# Altium schematic sheet Main.SchDoc
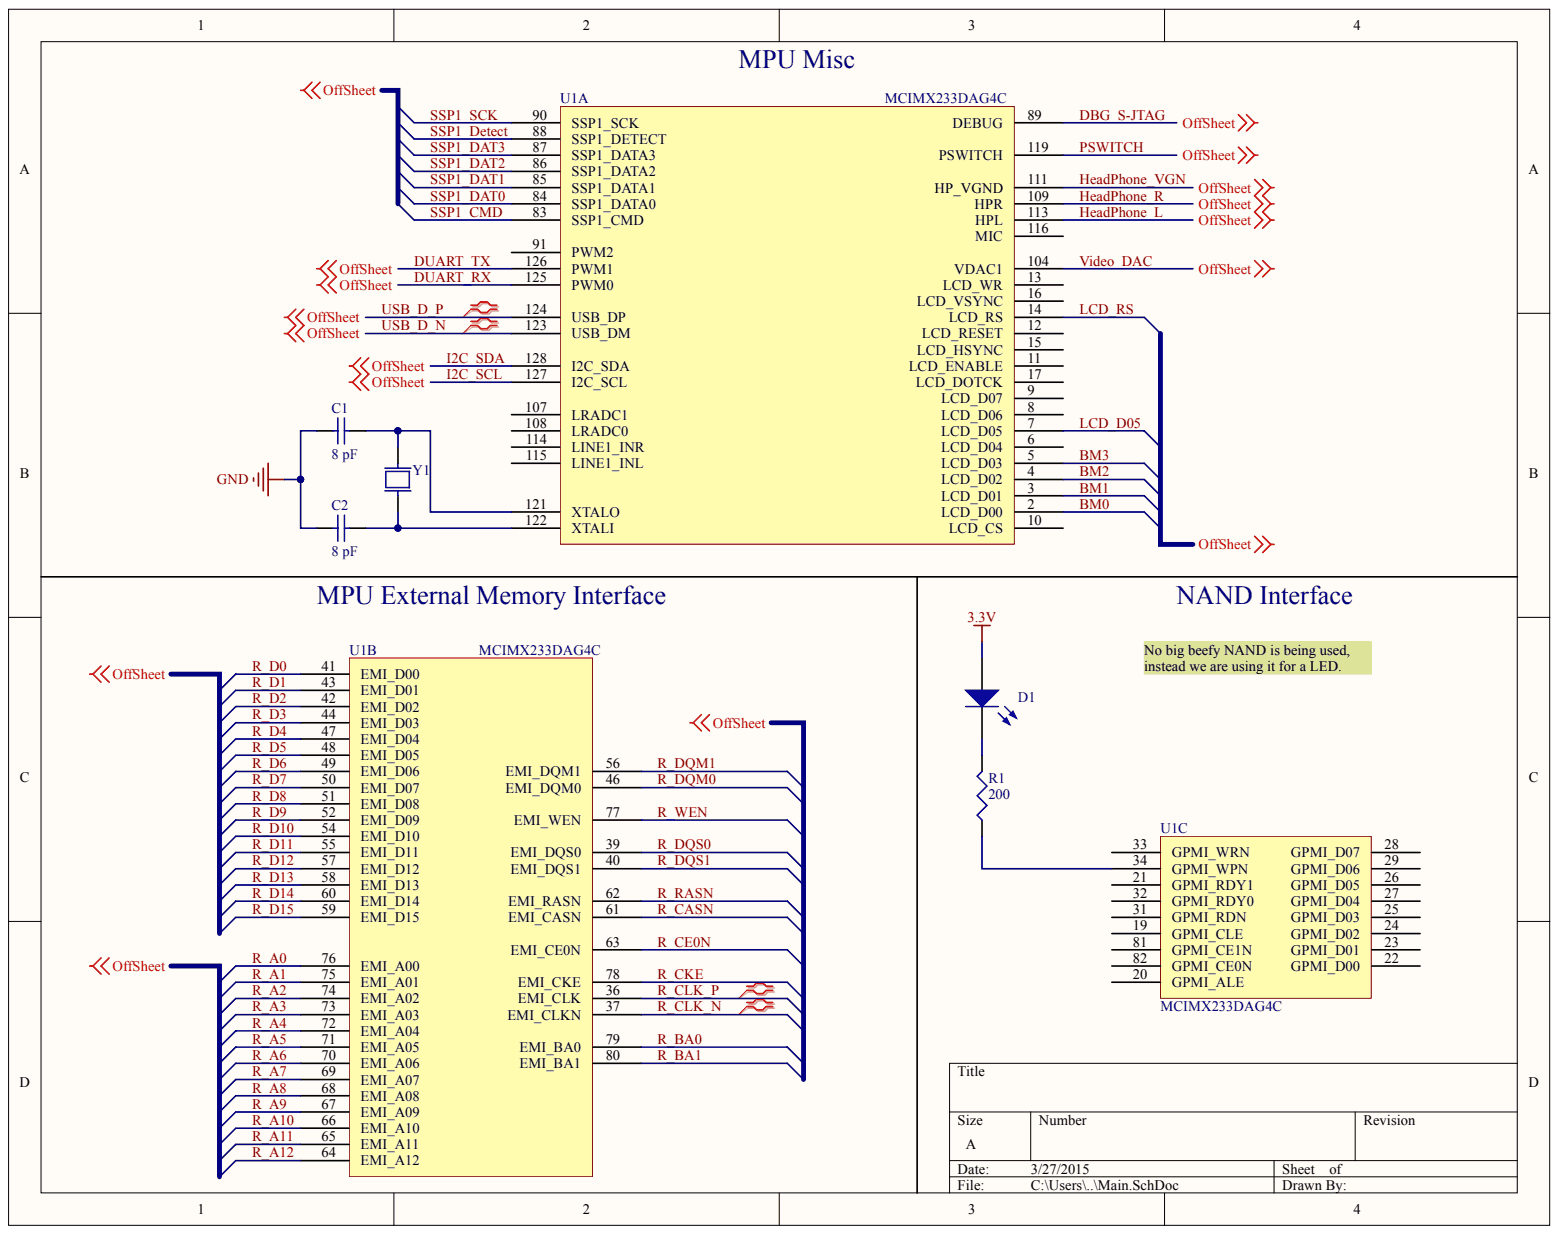
[diagram p0: Main.SchDoc]

## Parts
C1  value 8 pF  [0402]  (hidden comment: GRM1555C1H8R0DA01D)
C2  value 8 pF  [0402]  (hidden comment: GRM1555C1H8R0DA01D)
D1  LSQ976-Z  [LSQ976-Z]  (value not drawn)
R1  value 200  [J1-0603]  (hidden comment: MCR03ERTJ201)
U1  MCIMX233DAG4C  [TSQFP40P1600X1600X160-128N]  (parts A,B,C)
Y1  XRCGB24M000F3M00R0  [XRCGB24M000F3M00R0]  (value not drawn)

## Nets
3.3V: D1.A
GND: C1.2 C2.2
BM0: U1.2(LCD_D00)
BM1: U1.3(LCD_D01)
BM2: U1.4(LCD_D02)
BM3: U1.5(LCD_D03)
DBG_S-JTAG: U1.89(DEBUG)
DUART_RX: U1.125(PWM0)
DUART_TX: U1.126(PWM1)
HEADPHONE_L: U1.113(HPL)
HEADPHONE_R: U1.109(HPR)
HEADPHONE_VGN: U1.111(HP_VGND)
I2C_SCL: U1.127(I2C_SCL)
I2C_SDA: U1.128(I2C_SDA)
LCD_D05: U1.7(LCD_D05)
LCD_RS: U1.14(LCD_RS)
PSWITCH: U1.119(PSWITCH)
R_A0: U1.76(EMI_A00)
R_A1: U1.75(EMI_A01)
R_A2: U1.74(EMI_A02)
R_A3: U1.73(EMI_A03)
R_A4: U1.72(EMI_A04)
R_A5: U1.71(EMI_A05)
R_A6: U1.70(EMI_A06)
R_A7: U1.69(EMI_A07)
R_A8: U1.68(EMI_A08)
R_A9: U1.67(EMI_A09)
R_A10: U1.66(EMI_A10)
R_A11: U1.65(EMI_A11)
R_A12: U1.64(EMI_A12)
R_BA0: U1.79(EMI_BA0)
R_BA1: U1.80(EMI_BA1)
R_CASN: U1.61(EMI_CASN)
R_CE0N: U1.63(EMI_CE0N)
R_CKE: U1.78(EMI_CKE)
R_CLK_N: U1.37(EMI_CLKN)
R_CLK_P: U1.36(EMI_CLK)
R_D0: U1.41(EMI_D00)
R_D1: U1.43(EMI_D01)
R_D2: U1.42(EMI_D02)
R_D3: U1.44(EMI_D03)
R_D4: U1.47(EMI_D04)
R_D5: U1.48(EMI_D05)
R_D6: U1.49(EMI_D06)
R_D7: U1.50(EMI_D07)
R_D8: U1.51(EMI_D08)
R_D9: U1.52(EMI_D09)
R_D10: U1.54(EMI_D10)
R_D11: U1.55(EMI_D11)
R_D12: U1.57(EMI_D12)
R_D13: U1.58(EMI_D13)
R_D14: U1.60(EMI_D14)
R_D15: U1.59(EMI_D15)
R_DQM0: U1.46(EMI_DQM0)
R_DQM1: U1.56(EMI_DQM1)
R_DQS0: U1.39(EMI_DQS0)
R_DQS1: U1.40(EMI_DQS1)
R_RASN: U1.62(EMI_RASN)
R_WEN: U1.77(EMI_WEN)
SSP1_CMD: U1.83(SSP1_CMD)
SSP1_DAT0: U1.84(SSP1_DATA0)
SSP1_DAT1: U1.85(SSP1_DATA1)
SSP1_DAT2: U1.86(SSP1_DATA2)
SSP1_DAT3: U1.87(SSP1_DATA3)
SSP1_DETECT: U1.88(SSP1_DETECT)
SSP1_SCK: U1.90(SSP1_SCK)
USB_D_N: U1.123(USB_DM)
USB_D_P: U1.124(USB_DP)
VIDEO_DAC: U1.104(VDAC1)
NetC1_1: C1.1 U1.121(XTALO) Y1.3
NetC2_1: C2.1 U1.122(XTALI) Y1.1
NetD1_C: D1.C R1.1
NetR1_2: R1.2 U1.34(GPMI_WPN)
N00017:
N00018:
N00019:
N00020:
N00021:
OFFSHEET:

Not connected: U1 6(LCD_D04) 8(LCD_D06) 9(LCD_D07) 10(LCD_CS) 11(LCD_ENABLE) 12(LCD_RESET) 13(LCD_WR) 15(LCD_HSYNC) 16(LCD_VSYNC) 17(LCD_DOTCK) 19(GPMI_CLE) 20(GPMI_ALE) 21(GPMI_RDY1) 22(GPMI_D00) 23(GPMI_D01) 24(GPMI_D02) 25(GPMI_D03) 26(GPMI_D05) 27(GPMI_D04) 28(GPMI_D07) 29(GPMI_D06) 31(GPMI_RDN) 32(GPMI_RDY0) 33(GPMI_WRN) 81(GPMI_CE1N) 82(GPMI_CE0N) 91(PWM2) 107(LRADC1) 108(LRADC0) 114(LINE1_INR) 115(LINE1_INL) 116(MIC)

## Library data of the parts
C1 C2 = GRM1555C1H8R0DA01D: CAP CER 8PF 50V NP0 0402; Component Kind: Standard; LatestRevisionDate: 29-May-2009; LatestRevisionNote: IPC-7351 Footprint Added.; PackageDescription: Chip Capacitor; PackageReference: C1206; Published: 8-Jun-2000; Signal Integrity: Cap Semi; Simulation: CAP; Supplier 1: Digi-Key; Supplier Part Number 1: 490-5867-1-ND; Library Name: Linux_Board_SCHEM_LIB.SchLib; Publisher: Altium Limited
D1 = LSQ976-Z: OSRAM - LED, SMD, RED, 180MCD, 633NM; Component Kind: Standard; Signal Integrity: Guessed Model; Supplier 1: Mouser; Supplier 2: Newark; Supplier Part Number 1: N/A; Supplier Part Number 2: 05M0541; Library Name: Linux_Board_SCHEM_LIB.SchLib
R1 = MCR03ERTJ201: RES 200 OHM 1/10W 5% 0603 SMD; Component Kind: Standard; LatestRevisionDate: 29-May-2009; LatestRevisionNote: IPC-7351 Footprint Added.; PackageDescription: Chip Resistor; PackageReference: J1-0603; Published: 8-Jun-2000; Signal Integrity: Res; Simulation: RESISTOR; Supplier 1: Digi-Key; Supplier Part Number 1: RHM200CGCT-ND; Library Name: Linux_Board_SCHEM_LIB.SchLib; Publisher: Altium Limited
U1 = MX233DAG4C: IC MPU I.MX23 454MHZ 128LQFP; Component Kind: Standard; Supplier 1: Digi-Key; Supplier Part Number 1: MCIMX233DAG4C-ND; Library Name: Linux_Board_SCHEM_LIB.SchLib
Y1 = XRCGB24: CRYSTAL 24MHZ 6PF SMD; Component Kind: Standard; Signal Integrity: Cap; Simulation: CAP; Supplier 1: Digi-Key; Supplier Part Number 1: 490-5576-1-ND; Library Name: Linux_Board_SCHEM_LIB.SchLib
